# Altium schematic sheet Sheet4.SchDoc(Sheet4)
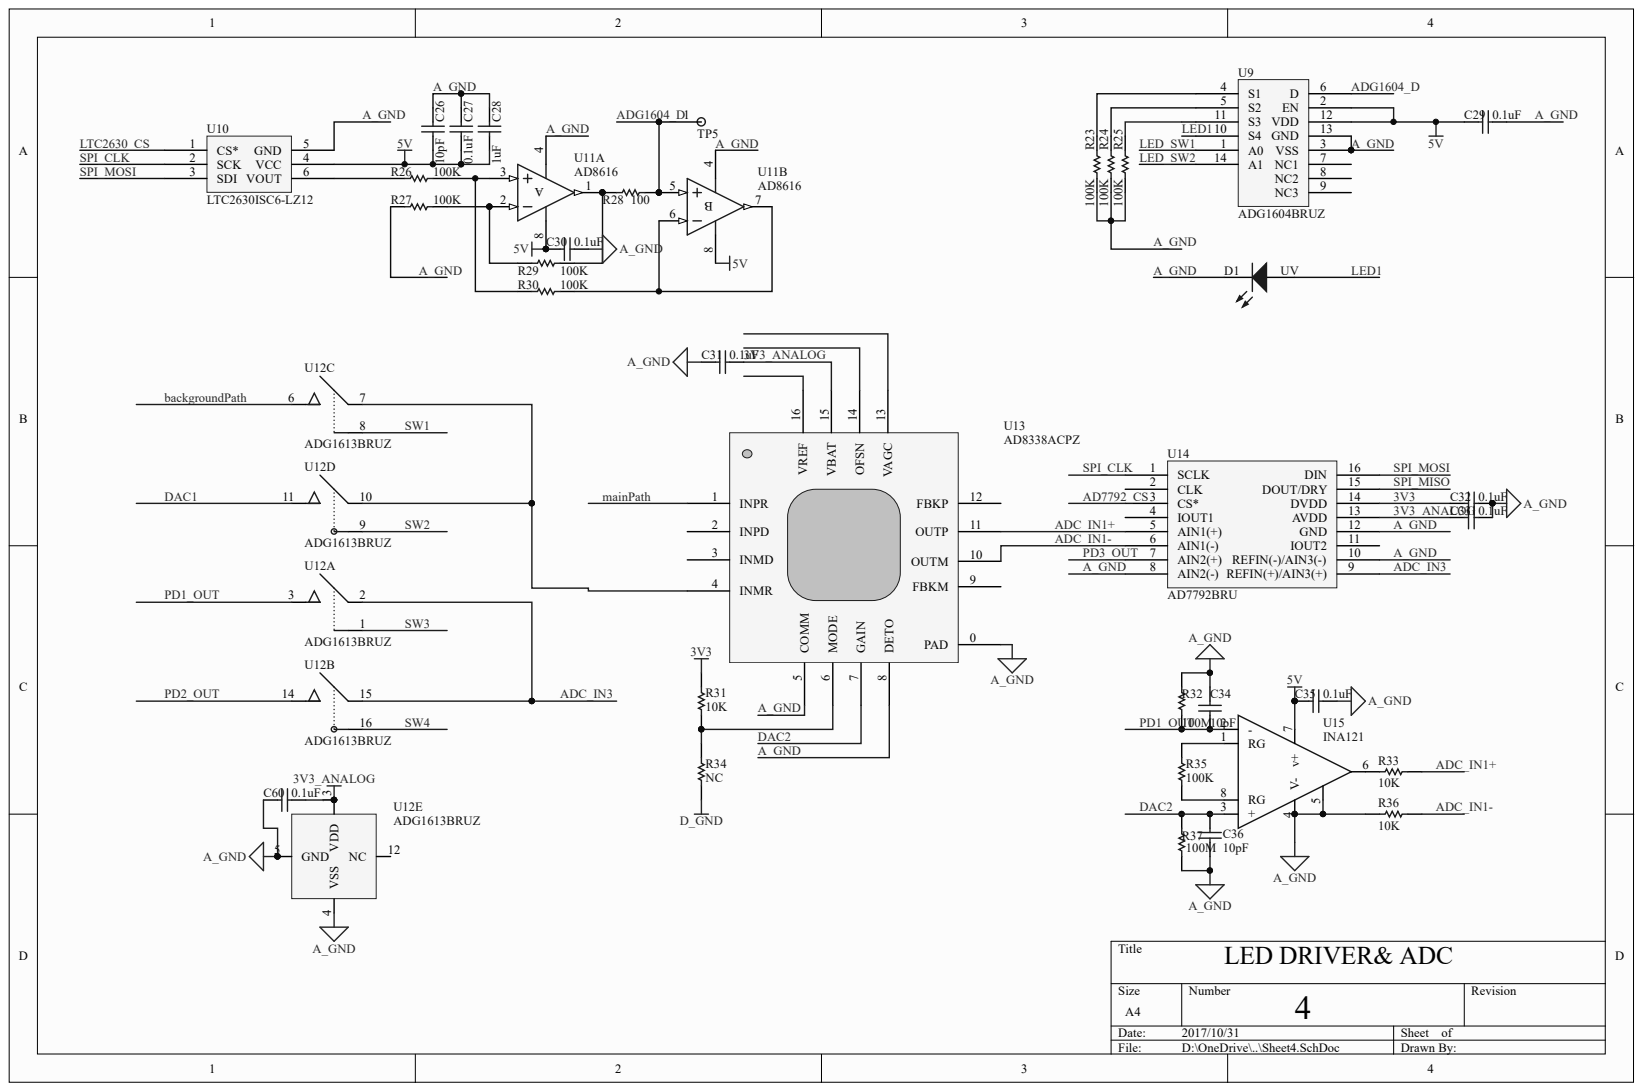
[diagram p0: Sheet4.SchDoc]

## Parts
C26  value 10pF  (hidden comment: Cap)
C27  value 0.1uF  (hidden comment: Cap)
C28  value 1uF  (hidden comment: Cap)
C29  value 0.1uF  (hidden comment: Cap)
C30  value 0.1uF  (hidden comment: Cap)
C31  value 0.1uF  (hidden comment: Cap)
C32  value 0.1uF  (hidden comment: Cap)
C33  value 0.1uF  (hidden comment: Cap)
C34  value 10pF  (hidden comment: Cap)
C35  value 0.1uF  (hidden comment: Cap)
C36  value 10pF  (hidden comment: Cap)
C60  value 0.1uF  (hidden comment: Cap)
D1  UV
R23  value 100K  (hidden comment: Res1)
R24  value 100K  (hidden comment: Res1)
R25  value 100K  (hidden comment: Res1)
R26  value 100K  (hidden comment: Res1)
R27  value 100K  (hidden comment: Res1)
R28  value 100  (hidden comment: Res1)
R29  value 100K  (hidden comment: Res1)
R30  value 100K  (hidden comment: Res1)
R31  value 10K  (hidden comment: Res1)
R32  value 100M  (hidden comment: Res1)
R33  value 10K  (hidden comment: Res1)
R34  value NC  (hidden comment: Res1)
R35  value 100K  (hidden comment: Res1)
R36  value 10K  (hidden comment: Res1)
R37  value 100M  (hidden comment: Res1)
TP5  Test Point  (value not drawn)
U9  ADG1604BRUZ
U10  LTC2630ISC6-LZ12
U11  AD8616  (parts A,B)
U12  ADG1613BRUZ  (parts A,B,C,D,E)
U13  AD8338ACPZ
U14  AD7792BRU
U15  INA121

## Nets
5V: C26.2 C27.2 C28.2 C29.2 C30.2 C35.2 U9.2(EN) U9.12(VDD) U10.4(VCC) U11.8 U15.7(v+)
D_GND: R34.1
3V3: C32.2 R31.2 U14.14(DVDD)
3V3_ANALOG: C31.1 C33.2 C60.2 U12.13(VDD) U13.15(VBAT) U14.13(AVDD)
A_GND: C26.1 C27.1 C28.1 C29.1 C30.1 C31.2 C32.1 C33.1 C34.1 C35.1 C36.2 C60.1 D1.2 R23.1 R24.1 R25.1 R27.2 R32.1 R36.1 R37.2 U9.3(VSS) U9.13(GND) U10.5(GND) U11.4 U12.4(VSS) U12.5(GND) U13.0(PAD) U13.5(COMM) U13.8(DETO) U14.8(AIN2(-)) U14.10(REFIN(-)/AIN3(-)) U14.12(GND) U15.4(V-) U15.5
AD7792_CS: U14.3(CS*)
ADC_IN1+: R33.2 U13.11(OUTP) U14.5(AIN1(+))
ADC_IN1-: R36.2 U13.10(OUTM) U14.6(AIN1(-))
ADC_IN3: U12.2 U12.15 U14.9(REFIN(+)/AIN3(+))
ADG1604_D: R28.1 TP5.1 U9.6(D) U11.5
BACKGROUNDPATH: U12.6
DAC1: U12.11
DAC2: C36.1 R37.1 U13.7(GAIN) U15.3(+)
LED1: D1.1 U9.10(S4)
LED_SW1: U9.1(A0)
LED_SW2: U9.14(A1)
LTC2630_CS: U10.1(CS*)
MAINPATH: U13.1(INPR)
PD1_OUT: C34.2 R32.2 U12.3 U15.2(-)
PD2_OUT: U12.14
PD3_OUT: U14.7(AIN2(+))
SPI_CLK: U10.2(SCK) U14.1(SCLK)
SPI_MISO: U14.15(DOUT/DRY)
SPI_MOSI: U10.3(SDI) U14.16(DIN)
SW1: U12.8
SW2: U12.9
SW3: U12.1
SW4: U12.16
NetR23_2: R23.2 U9.4(S1)
NetR24_2: R24.2 U9.5(S2)
NetR25_2: R25.2 U9.11(S3)
NetR26_1: R26.1 R30.2 U11.3
NetR26_2: R26.2 U10.6(VOUT)
NetR27_1: R27.1 R29.2 U11.2
NetR28_2: R28.2 R29.1 U11.1
NetR30_1: R30.1 U11.6 U11.7
NetR31_1: R31.1 R34.2 U13.6(MODE)
NetR33_1: R33.1 U15.6
NetR35_1: R35.1 U15.1(RG)
NetR35_2: R35.2 U15.8(RG)
NetU12_7: U12.7 U12.10 U13.4(INMR)

Not connected: U9 7(NC1) 8(NC2) 9(NC3); U12 12(NC); U13 2(INPD) 3(INMD) 9(FBKM) 12(FBKP) 13(VAGC) 14(OFSN) 16(VREF); U14 2(CLK) 4(IOUT1) 11(IOUT2)

## Library data of the parts
C26-C36 C60 D1 R23-R37 TP5 U9-U15 = (no symbol name)
  C26-C36 C60: Published: 8-Jun-2000; LatestRevisionDate: 17-Jul-2002; LatestRevisionNote: Re-released for DXP Platform.; PackageReference: RAD-0.3
  D1: Published: 8-Jun-2000; LatestRevisionDate: 08-Jul-2005; LatestRevisionNote: Mech Layer 1 removed.; PackageReference: LED-0
  R23-R37: Published: 8-Jun-2000; LatestRevisionDate: 17-Jul-2002; LatestRevisionNote: Re-released for DXP Platform.; PackageReference: AXIAL-0.3
  U11: Published: 8-Jun-2000; LatestRevisionDate: 13-Apr-2006; LatestRevisionNote: IPC-7351 Footprint Added.; DatasheetVersion: REV. B 1998; PackageVersion: revA; PackageReference: SO-8; PackageDescription: 8-Pin Small Outline Integrated Circuit 1.27 mm Pitch; Code_JEDEC: MS-012-AA; Code_IPC: SOIC127P600-8; ComponentLink1Description: Manufacturer Link; ComponentLink1URL: http://www.analog.com/en/prod/0,,759_786_AD812%2C00.html; ComponentLink2Description: Datasheet; ComponentLink2URL: http://www.analog.com/UploadedFiles/Data_Sheets/200001408AD812_b.pdf
  C26-C36 C60 D1 R23-R37 U11: Publisher: Altium Limited
